# Altium schematic sheet CurrentMeasure_InputProcessing.SchDoc(CurrentMeasure_InputProcessing)
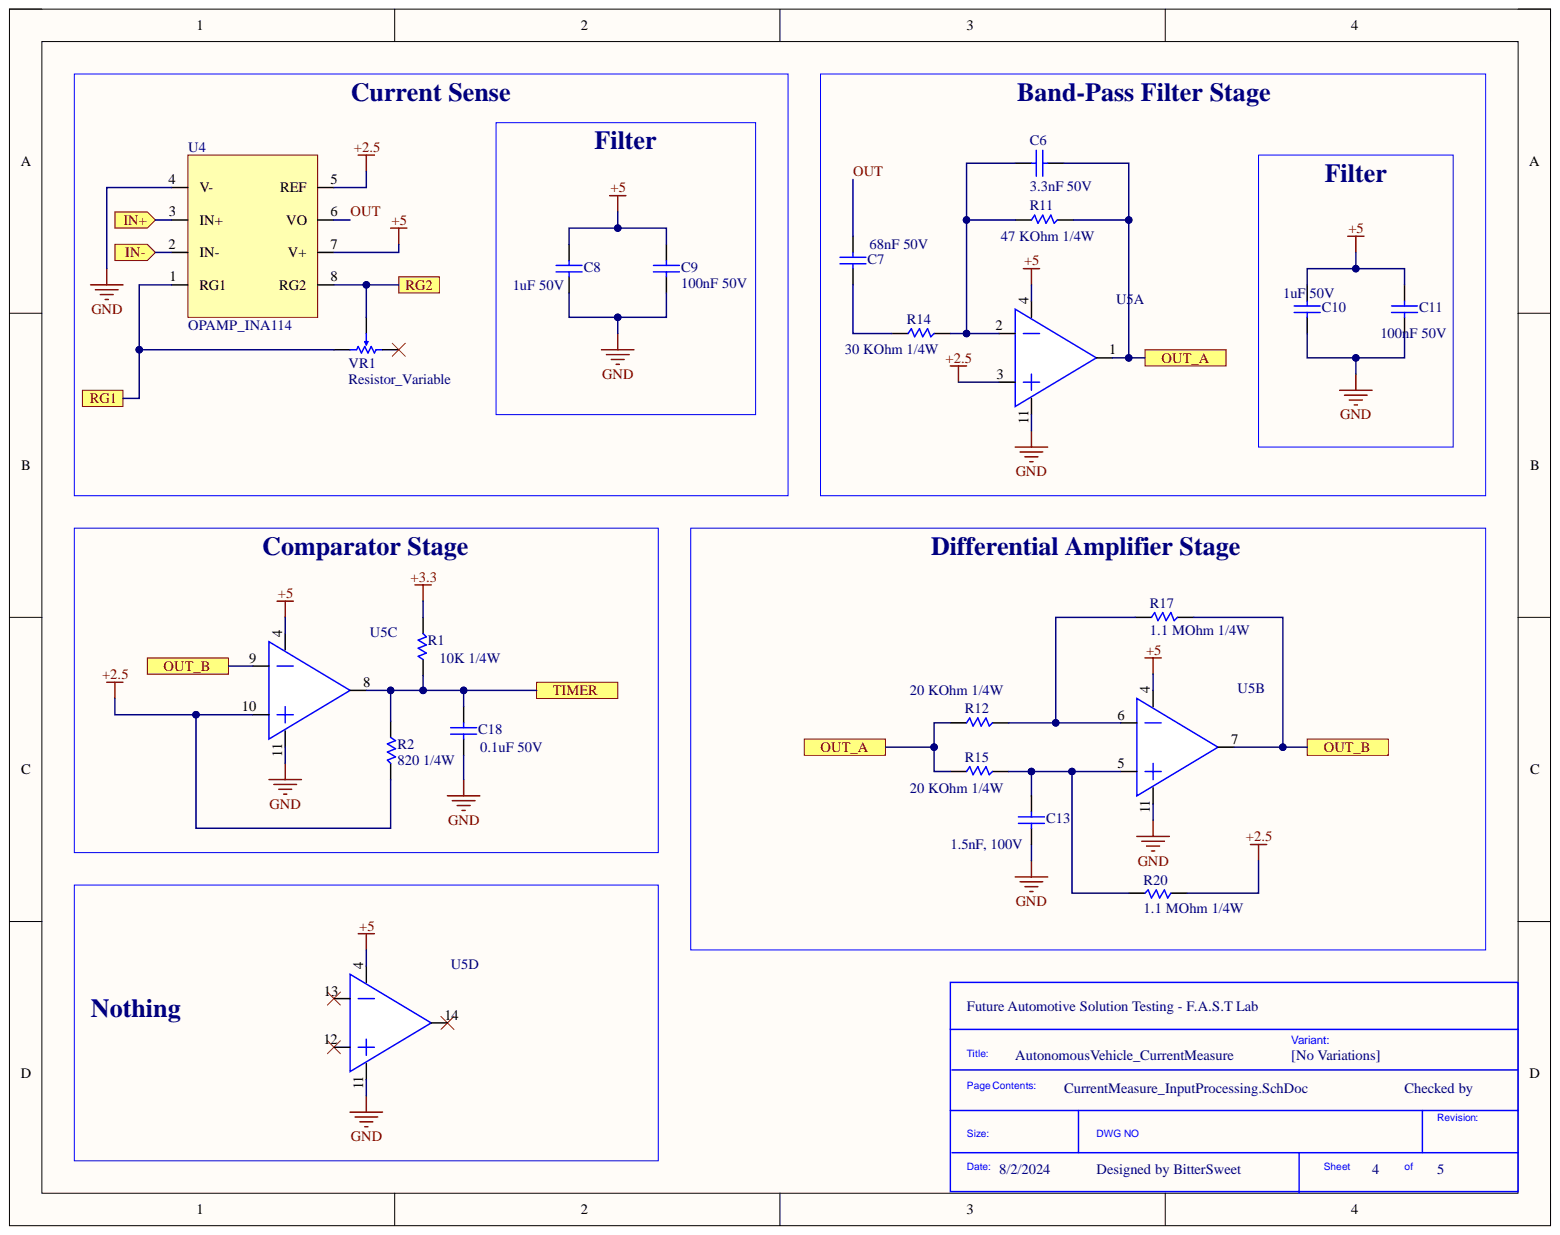
[diagram p0: CurrentMeasure_InputProcessing.SchDoc]

## Parts
C6  3.3nF 50V  [CAP_RADIAL_2.54]
C7  68nF 50V  [CAP_RADIAL_2.54]
C8  1uF 50V  [CAP_RADIAL_2.54]
C9  100nF 50V  [CAP_RADIAL_2.54]
C10  1uF 50V  [CAP_RADIAL_2.54]
C11  100nF 50V  [CAP_RADIAL_2.54]
C13  1.5nF, 100V  [Mica_CAP]
C18  0.1uF 50V  [CAP_RADIAL_2.54]
R1  10K 1/4W  [RESISTOR-1/4W]
R2  820 1/4W  [RESISTOR-1/4W]
R11  47 KOhm 1/4W  [RESISTOR-1/4W]
R12  20 KOhm 1/4W  [RESISTOR-1/4W]
R14  30 KOhm 1/4W  [RESISTOR-1/4W]
R15  20 KOhm 1/4W  [RESISTOR-1/4W]
R17  1.1 MOhm 1/4W  [RESISTOR-1/4W]
R20  1.1 MOhm 1/4W  [RESISTOR-1/4W]
U4  OPAMP_INA114  [INA114AP]
U5  IC_OPAMP_LM324N  [LM324_DIP14]  (value not drawn)  (parts A,B,C,D)
VR1  Resistor_Variable  [RESISTOR_VARIABLE]

## Ports
IN+, IN-, OUT_A, OUT_B, RG1, RG2, TIMER

## Nets
+2.5: R2.2 R20.1 U4.5(REF) U5.3 U5.10
+3.3: R1.2
+5: C8.1 C9.1 C10.1 C11.1 U4.7(V+) U5.4
GND: C8.2 C9.2 C10.2 C11.2 C13.2 C18.1 U4.4(V-) U5.11
OUT: C7.2 U4.6(VO)
OUT_A: C6.1 R11.2 R12.1 R15.1 U5.1 ; port OUT_A
OUT_B: R17.2 U5.7 U5.9 ; port OUT_B
RG1: U4.1(RG1) VR1.1 ; port RG1
RG2: U4.8(RG2) VR1.2 ; port RG2
TIMER: C18.2 R1.1 R2.1 U5.8 ; port TIMER
NetC6_2: C6.2 R11.1 R14.2 U5.2
NetC7_1: C7.1 R14.1
NetC13_1: C13.1 R15.2 R20.2 U5.5
NetR12_2: R12.2 R17.1 U5.6
NetU4_2: U4.2(IN-)
NetU4_3: U4.3(IN+)

Not connected: U5 12 13 14; VR1 3

## Library data of the parts
C6 = Capacitor: Capacitor, 3.3nF 50V; Component Kind: Standard; URL: https://www.thegioiic.com/tu-gom-tron-3-3nf-50v; Library Name: AutonomousVehicle_Lib.IntLib
C7 = Capacitor: Capacitor, 68nF 50V; Component Kind: Standard; URL: https://www.thegioiic.com/tu-gom-tron-68nf-50v; Library Name: AutonomousVehicle_Lib.IntLib
C8 C10 = Capacitor: Capacitor 1uF 50V; Component Kind: Standard; URL: https://www.thegioiic.com/tu-gom-multilayer-1uf-50v; Library Name: AutonomousVehicle_Lib.IntLib
C9 C11 = Capacitor: Capacitor 100nF 50V; Component Kind: Standard; URL: https://www.thegioiic.com/tu-gom-tron-100nf-0-1uf-50v; Library Name: AutonomousVehicle_Lib.IntLib
C13 = Capacitor: Component Kind: Standard; Description: Capacitor; Library Name: AutonomousVehicle_Lib.IntLib
C18 = Capacitor: Capacitor, 0.1uF 50V; Component Kind: Standard; URL: https://www.thegioiic.com/tu-gom-tron-100nf-0-1uf-50v; Library Name: AutonomousVehicle_Lib.IntLib
R1 = RESISTOR: Resistor 10 KOhm 1/4W; MP: NUCLEO-F401RE; Availability: Unavailable; Component Kind: Standard; ComponentLink1Description: URL; ComponentLink1URL: https://www.thegioiic.com/dien-tro-10-kohm-1-4w-1-5-vong-mau; Package: None; Price: None; Library Name: AutonomousVehicle_Lib.IntLib
R2 = RESISTOR: Resistor 820 Ohm 1/4W; MP: NUCLEO-F401RE; Availability: Unavailable; Component Kind: Standard; ComponentLink1Description: URL; ComponentLink1URL: https://www.thegioiic.com/dien-tro-820-ohm-1-4w-1-5-vong-mau; Package: None; Price: None; Library Name: AutonomousVehicle_Lib.IntLib
R11 R14 = RESISTOR: MP: NUCLEO-F401RE; Availability: Unavailable; Component Kind: Standard; Package: None; Price: None; Library Name: AutonomousVehicle_Lib.IntLib
  R11: Description: 47 KOhm 1/4W; URL: https://www.thegioiic.com/dien-tro-47-kohm-1-4w-1-5-vong-mau
  R14: Description: 30 KOhm 1/4W; URL: https://www.thegioiic.com/dien-tro-30-kohm-1-4w-1-5-vong-mau
R12 R15 = RESISTOR: Resistor 20 KOhm 1/4W; MP: NUCLEO-F401RE; Availability: Unavailable; Component Kind: Standard; ComponentLink1Description: URL; ComponentLink1URL: https://www.thegioiic.com/dien-tro-20-kohm-1-4w-1-5-vong-mau; Package: None; Price: None; Library Name: AutonomousVehicle_Lib.IntLib
R17 = RESISTOR: Resistor 1.1 MOhm 1/4W; MP: NUCLEO-F401RE; Availability: Unavailable; Component Kind: Standard; ComponentLink1Description: URL; ComponentLink1URL: https://www.thegioiic.com/dien-tro-1-mohm-1-4w-1-5-vong-mau; Package: None; Price: None; Library Name: AutonomousVehicle_Lib.IntLib
R20 = RESISTOR: Resistor 1 MOhm 1/4W; MP: NUCLEO-F401RE; Availability: Unavailable; Component Kind: Standard; ComponentLink1Description: URL; ComponentLink1URL: https://www.thegioiic.com/dien-tro-1-mohm-1-4w-1-5-vong-mau; Package: None; Price: None; Library Name: AutonomousVehicle_Lib.IntLib
U4 = OPAMP_INA114: INA114AP IC Instrumentation Amplifier 1MHZ, 8-DIP; Component Kind: Standard; URL: https://www.thegioiic.com/products?search=INA114; Library Name: AutonomousVehicle_Lib.IntLib
U5 = IC_OPAMP_LM324N: LM324N IC OPAMP General Purpose Amplifier 4 Circuit 1.2MHZ ,14-DIP; Component Kind: Standard; URL: https://www.thegioiic.com/lm324n-ic-opamp-general-purpose-amplifier-4-circuit-1-2mhz-14-dip; Library Name: AutonomousVehicle_Lib.IntLib
VR1 = Resistor_Variable: Variable Resister, 3 pins, 10 KOhm; Component Kind: Standard; Library Name: AutonomousVehicle_Lib.IntLib
